# Altium schematic sheet SD Card Breakout with USB.SchDoc
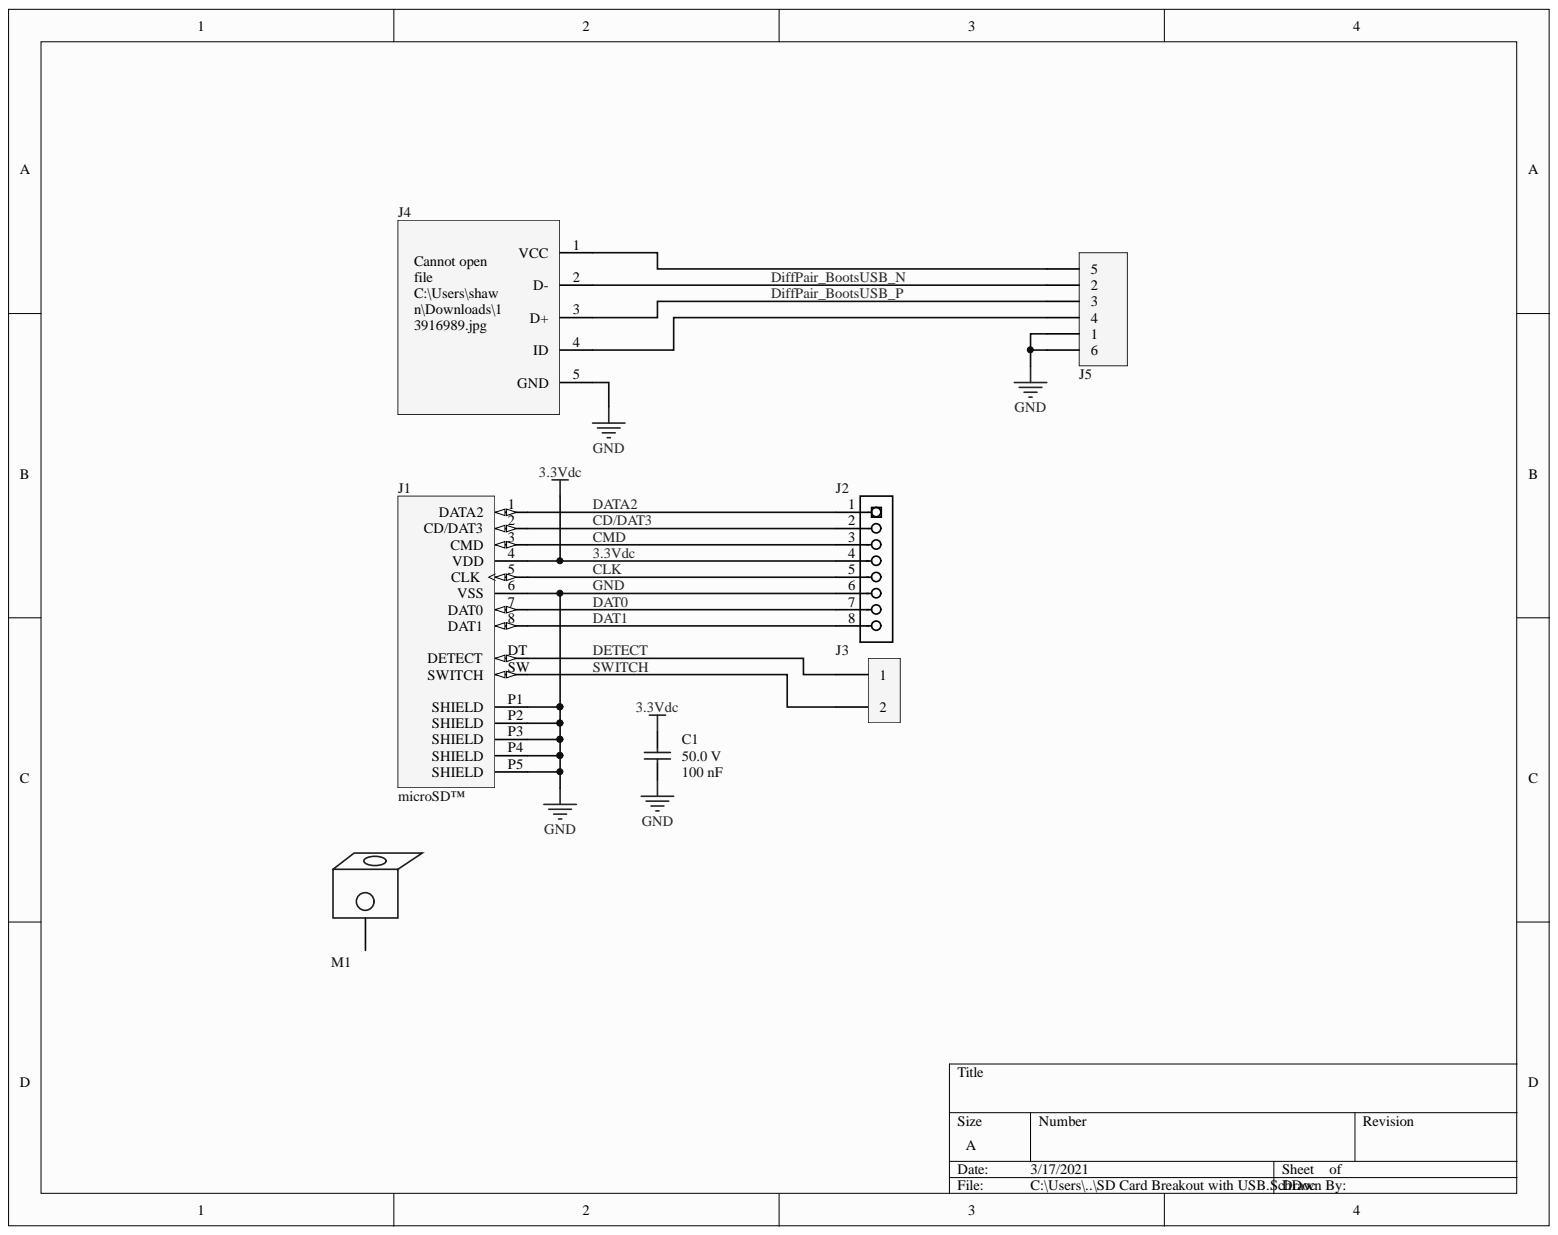
[diagram p0: SD Card Breakout with USB.SchDoc]

## Parts
C1  Cap  [Cap,0805]  (value not drawn)
J1  Card Type: microSD™  [Conn,SD micro,503182-1852]  (hidden comment: 5031821852)
J2  HEADER,0.1",8Pin,SinlgeRow  [Header,8Pin,SingleRow, RA,0.1"]  (value not drawn)
J3  Generic Header  [HEADER-1X2,0.1",RA1]  (value not drawn)
J4  USB3090-30-A  [Conn,USB micro,AB, Receptacle,USB3090-30-A]  (value not drawn)
J5  Header, through hole,vertical, 6pin,1 Row,0.1", M20-9991245  [Header, 6 pin, 0.1" Spaceing - RA]  (value not drawn)
M1  4334  [Mech,Bracket,RA,#6,Threaded]  (value not drawn)

## Nets
3.3VDC: C1.2 J1.4(VDD) J2.4
CD/DAT3: J1.2(CD/DAT3) J2.2
CLK: J1.5(CLK) J2.5
CMD: J1.3(CMD) J2.3
DAT0: J1.7(DAT0) J2.7
DAT1: J1.8(DAT1) J2.8
DATA2: J1.1(DATA2) J2.1
DETECT: J1.DT(DETECT) J3.1
DIFFPAIR_BOOTSUSB_N: J4.2(D-) J5.2
DIFFPAIR_BOOTSUSB_P: J4.3(D+) J5.3
GND: C1.1 J1.6(VSS) J1.P1(SHIELD) J1.P2(SHIELD) J1.P3(SHIELD) J1.P4(SHIELD) J1.P5(SHIELD) J2.6 J4.5(GND) J4.6 J4.7 J4.8 J4.9 J5.1 J5.6
SWITCH: J1.SW(SWITCH) J3.2
NetJ4_1: J4.1(VCC) J5.5
NetJ4_4: J4.4(ID) J5.4

Not connected: M1 1

## Library data of the parts
C1 = Cap,0805,100nf,50V,5%,08055C104JAT2A: Capacitor; Ceramic; Cap 0.1 uF; Tol 5%; Vol-Rtg 50 VDC; SMT; 0805; X7R; Tape & Reel; Capacitance: 100 nF; Case/Package (SI): 2012; Detailed Description: 0.1µF ±5% 50V Ceramic Capacitor X7R 0805 (2012 Metric); Insulation Resistance: 100 GΩ; Lead-Free Status: Lead Free; Voltage Rating (DC): 50.0 V; Capacitance Tolerance: ±5%; Case/Package: 0805; Component Kind: Standard; Dielectric Characteristic: X7R; digikey url: http://www.digikey.ca/product-detail/en/avx-corporation/08055C104JAT2A/478-3352-1-ND/930144; Library Name: Master JULY2019.SchLib; Manufacturer Part Number: 08055C104JAT2A; Mounting Style: Surface Mount; Number of Pins: 2; Octopart URL: http://octopart.com/08055c104jat2a-avx-55388010; Operating Temperature: -55°C ~ 125°C; Packaging: Tape & Reel (TR), Cut Tape (CT); REACH SVHC Compliance: No SVHC; RoHS: Compliant; Size-Height: 710 µm; Size-Length: 2.01 mm; Size-Thickness: 940 µm; Size-Width: 1.24 mm
J1 = Conn,SD Micro,10 (8 + 2) Position,SMD,RA,5031821852: MICRO SD NORMAL ULTRALOWPRO8CKTE; Manufacturer: Molex; Categories: Connectors, Interconnects   Memory Connectors - PC Card Sockets; Connector Type: Connector and Ejector; Detailed Description: 10 (8 + 2) Position Card Connector microSD™ Surface Mount, Right Angle Gold; Insertion, Removal Method: Push In, Push Out; Component Kind: Standard; Contact Finish: Gold; Features: Switch; Height Above Board: 0.057" (1.45mm); Library Name: Master JULY2019.SchLib; mfg P/N: 5031821852; Mounting Feature: Normal, Standard - Top; Mounting Type: Surface Mount, Right Angle; Number of Positions: 10 (8 + 2); Part Status: Active; Series: 503182
J3 = Header 1x2,0.1"Spacing: Component Kind: Standard; Library Name: Master JULY2019.SchLib
J4 = Conn,SMD,USB Micro AB,Recept,USB 2.0,  USB3090-30-A: MICRO A/B SKT,BOTTOM-SMT, R/A, 3; Manufacturer: GCT; Categories: Connectors, Interconnects   USB, DVI, HDMI Connectors; Connector Type: USB - micro AB; Current Rating (Amps): 1A, 1.8A; Detailed Description: USB - micro AB USB 2.0 Receptacle Connector 5 Position Surface Mount, Right Angle; Through Hole; Gender: Receptacle; Material Flammability Rating: UL94 V-0; Mating Cycles: 10000; Number of Contacts: 5; Number of Ports: 1; Shielding: Shielded; Specifications: USB 2.0; Voltage - Rated: 30VAC; Component Kind: Standard; Contact Finish: Gold; Features: Solder Retention; Insulation Color: Black; Library Name: Master SEPT2019.SchLib; mfg P/N: USB3090-30-A; Mounting Feature: Bottom Mount, Horizontal; Mounting Type: Surface Mount, Right Angle; Through Hole; Operating Temperature: -30°C ~ 85°C; Part Status: Active; Series: USB3090; Shell/Shielding Material: Steel, Stainless (SUS); Termination: Solder
J5 = Header, through hole,vertical, 6pin,1 Row,0.1", M20-9991245: 2.54mm (0.1") Pitch SIL Vertical PC Tail Pin Header, 6.1mm mating pin height, gold (hi-temp mould), 6 contacts; Manufacturer Part Number: M20-9990645; Contact Resistance: 15.0 mΩ; Current Rating: 3.00 A; Gender: Male; Insulation Resistance: 10.0 GΩ; Number of Contacts: 6; Number of Mating Cycles: 300; Voltage Rating (AC): 750 V; Component Kind: Standard; Contact Material: Phosphor Bronze; Insulation Material: Polyester; Library Name: Master JULY2019.SchLib; Mounting Style: Through Hole, Vertical; Pin Pitch: 2.54 mm; REACH SVHC Compliance: No SVHC; RoHS: Compliant; Supplier 1: Digi-Key
M1 = Mech,Bracket,RA,Threaded,4334: Mounting Bracket Short L #6-32 (2) Steel, Nickel Plated; Manufacturer: Keystone Electronics; Thread/Screw/Hole: Size #6-32 (2); Component Kind: Standard; Library Name: Master JULY2019.SchLib; Material: Steel, Nickel Plated; mfg P/N: 4334; Type: Bracket, Threaded Hole(s)
J2 = HEADER,0.1",8Pin,SinlgeRow: Component Kind: Standard; Library Name: Master JULY2019.SchLib; Supplier 1: Digi-Key; Supplier Part Number 1: S1011E-36-ND
